# Altium schematic sheet [20]-CONNECT_CONNECTORS.SchDoc([20]-CONNECT_CONNECTORS)
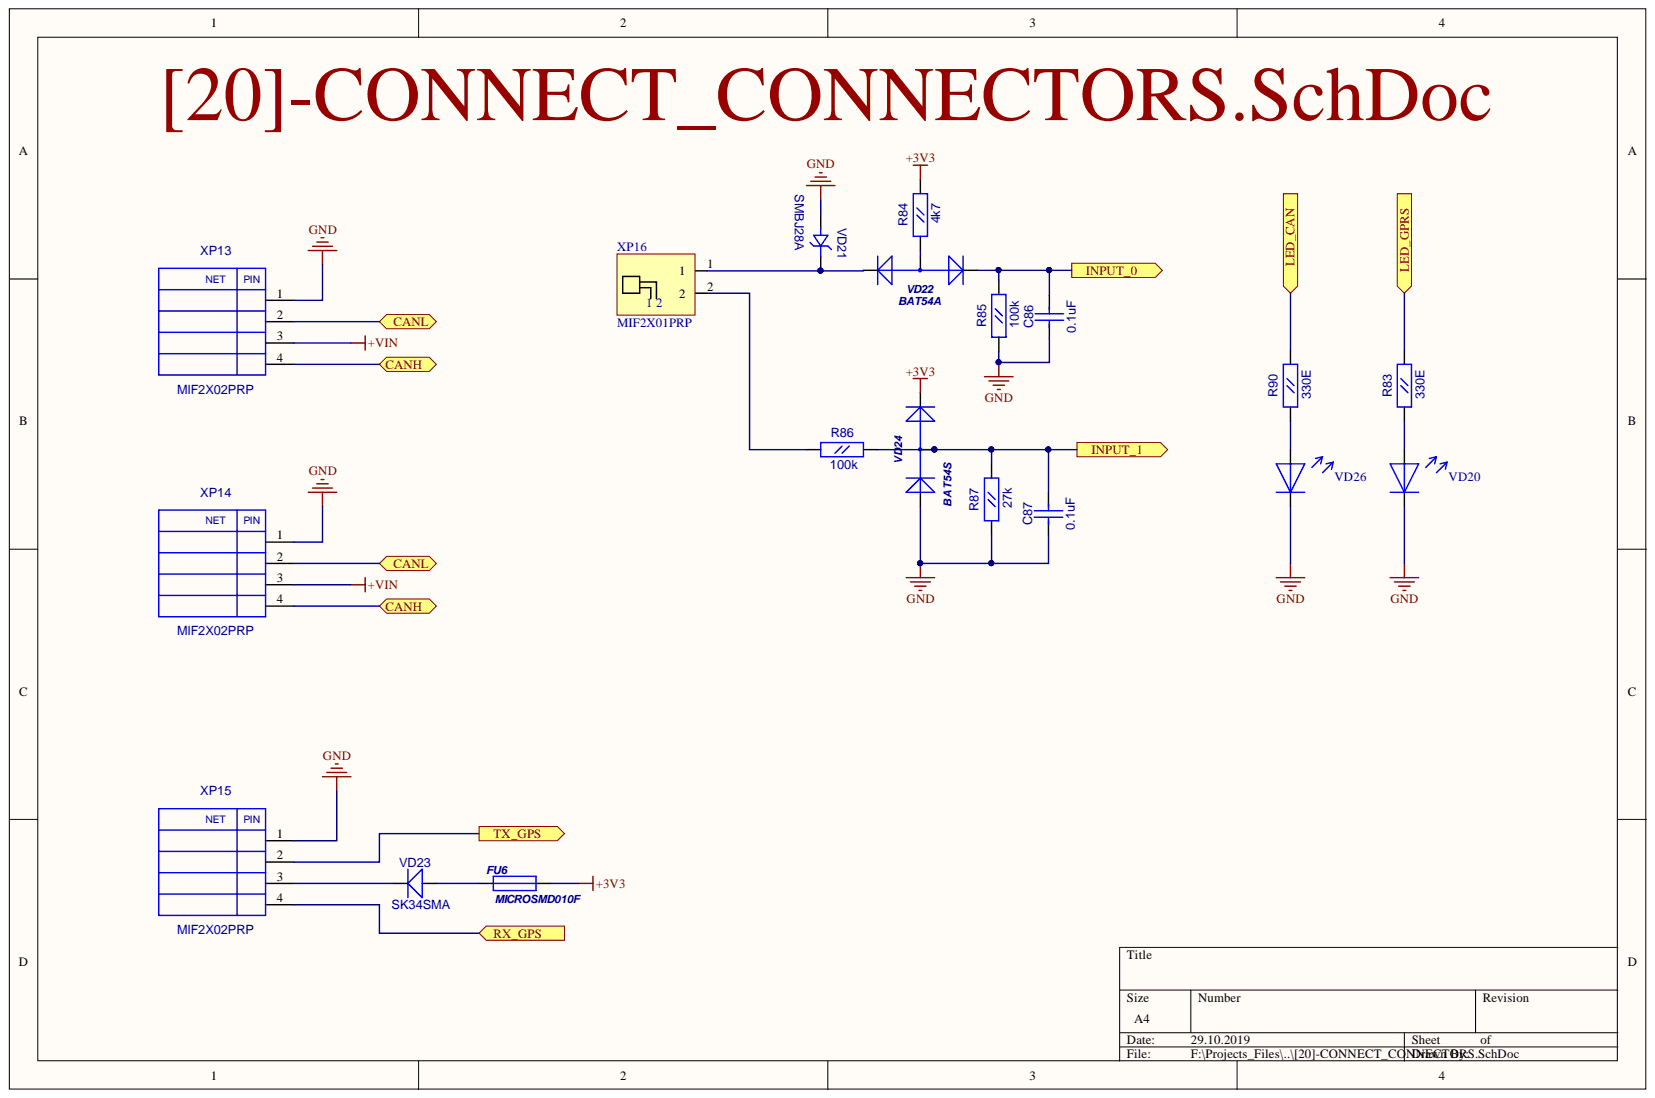
[diagram p0: [20]-CONNECT_CONNECTORS.SchDoc]

## Parts
C86  0.1uF  [CC0603]
C87  0.1uF  [CC0603]
FU6  MICROSMD010F  [RC1210]
R83  330E  [RC0603]
R84  4k7  [RC0603]
R85  100k  [RC0603]
R86  100k  [RC0603]
R87  27k  [RC0603]
R90  330E  [RC0603]
VD20  LED_0805  [LED0805]  (value not drawn)
VD21  SMBJ28A  [SMB]
VD22  BAT54A  [SOT-23(123)]
VD23  SK34SMA  [SMA]
VD24  BAT54S  [SOT-23(GSD)]
VD26  LED_0805  [LED0805]  (value not drawn)
XP13  MIF2X02PRP  [MIF2X02PRP]
XP14  MIF2X02PRP  [MIF2X02PRP]
XP15  MIF2X02PRP  [MIF2X02PRP]
XP16  MIF2X01PRP  [MIF2x01PRP]

## Ports
CANH, CANL, INPUT_0, INPUT_1, LED_CAN, LED_GPRS, RX_GPS, TX_GPS

## Nets
+3V3: FU6.2 R84.2 VD24.2
+VIN: XP13.3 XP14.3
GND: C86.1 C87.1 R85.1 R87.1 VD20.2 VD21.1 VD24.1 VD26.2 XP13.1 XP14.1 XP15.1
CANH: XP13.4 XP14.4 ; port CANH
CANL: XP13.2 XP14.2 ; port CANL
INPUT_0: C86.2 R85.2 VD22.2 ; port INPUT_0
INPUT_1: C87.2 R86.2 R87.2 VD24.3 ; port INPUT_1
LED_CAN: R90.2 ; port LED_CAN
LED_GPRS: R83.2 ; port LED_GPRS
RX_GPS: XP15.4 ; port RX_GPS
TX_GPS: XP15.2 ; port TX_GPS
NetFU6_1: FU6.1 VD23.1
NetR83_1: R83.1 VD20.1
NetR84_1: R84.1 VD22.3
NetR86_1: R86.1 XP16.2
NetR90_1: R90.1 VD26.1
NetVD21_2: VD21.2 VD22.1 XP16.1
NetVD23_2: VD23.2 XP15.3

## Library data of the parts
C86 C87 = CC0603: Value: 0.1uF; Description: CC0603; Component Kind: Standard
  C86: Library Name: fizik_lib.SchLib
  C87: Library Name: gprs_modem_2.SchLib
FU6 = MICROSMD: Value: MICROSMD010F; Description: MICROSMD; Component Kind: Standard; Library Name: fizik_lib.SchLib
R83-R87 R90 = RC0603: Description: RC0603; Component Kind: Standard; Library Name: fizik_lib.SchLib
  R83 R90: Value: 330E
  R84: Value: 4k7
  R85 R86: Value: 100k
  R87: Value: 27k
VD21 = SMBJ: Value: SMBJ28A; Description: SMBJ; Component Kind: Standard; Library Name: fizik_lib.SchLib
VD22 = BAT54A: 1.0 Ampere General Purpose Rectifier; ComponentField6: Published 8-Jun-2000, Modified 11-July-2000: SIM Model Added.; Simulation: DIODE; Component Kind: Standard; Library Name: fizik_lib.SchLib
VD23 = SK34SMA: Schottky Rectifier; Value: {Value}; Component Kind: Standard; Library Name: fizik_lib.SchLib
VD24 = BAT54S: 1.0 Ampere General Purpose Rectifier; ComponentField6: Published 8-Jun-2000, Modified 11-July-2000: SIM Model Added.; Simulation: DIODE; Component Kind: Standard; Library Name: fizik_lib.SchLib
XP16 = MIF2X01PRP: Molex connector 3.0mm pitch; Value: MIF2X01PRP; Component Kind: Standard; Library Name: fizik_lib.SchLib
VD20 VD26 = LED_0805: Value: LED_0805; Component Kind: Standard; Library Name: fizik_lib.SchLib
XP13-XP15 = MIF2X02PRP: Description: MIF2X02PRP; Component Kind: Standard; Library Name: fizik_lib.SchLib
